# Altium schematic sheet RC96_sht4.SchDoc
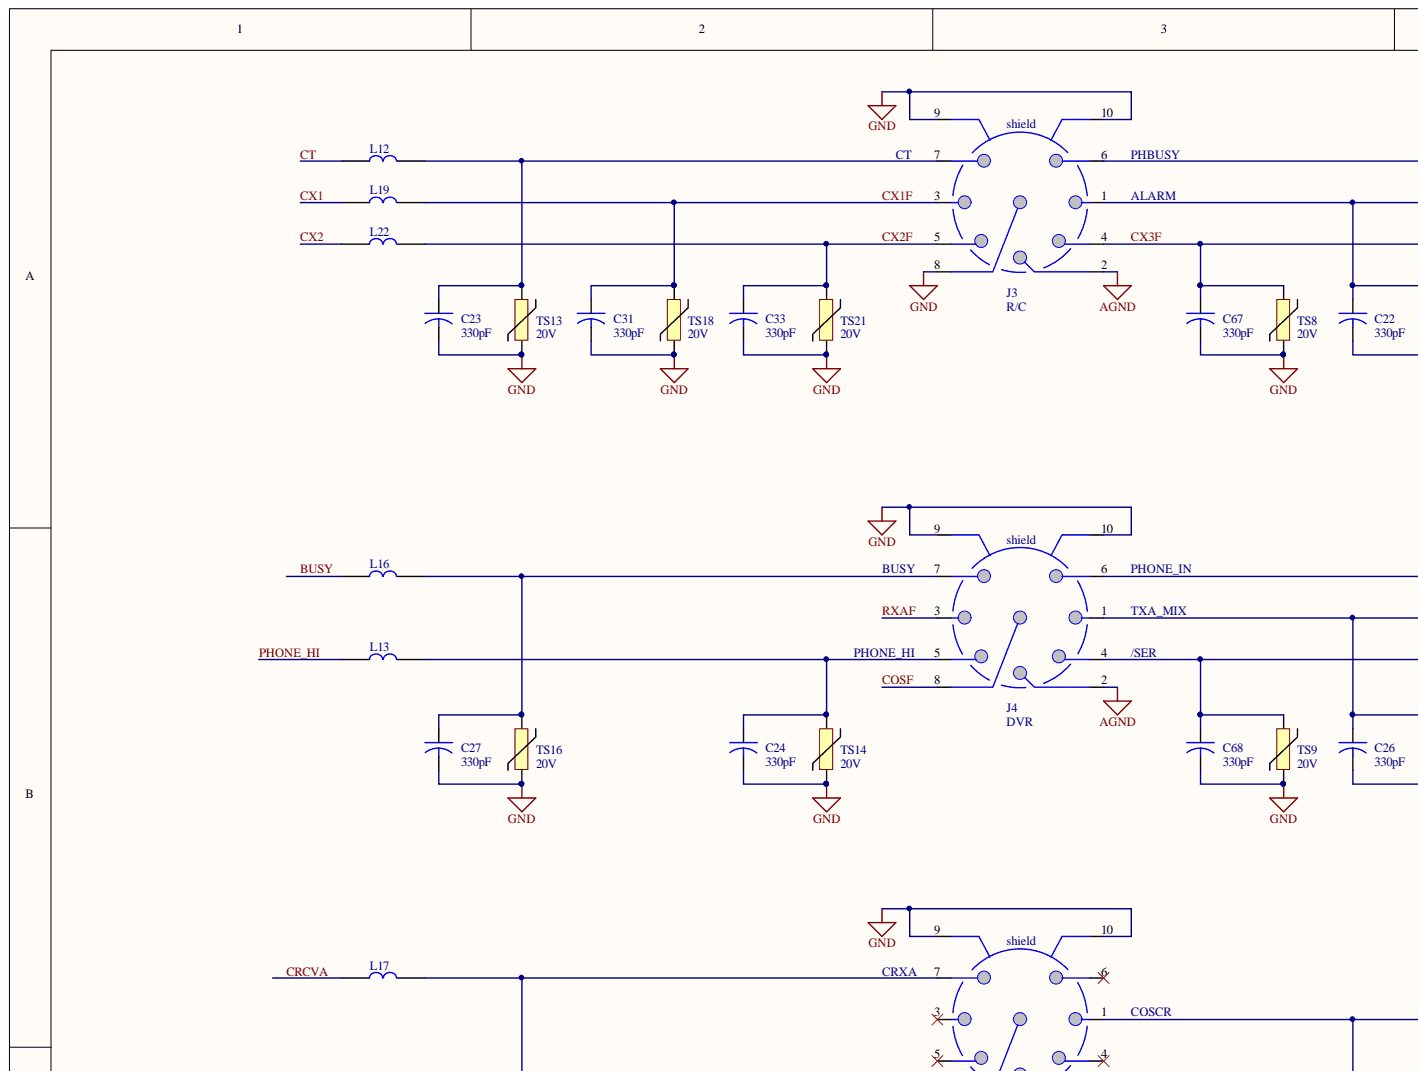
[diagram p0: RC96_sht4.SchDoc, top left]
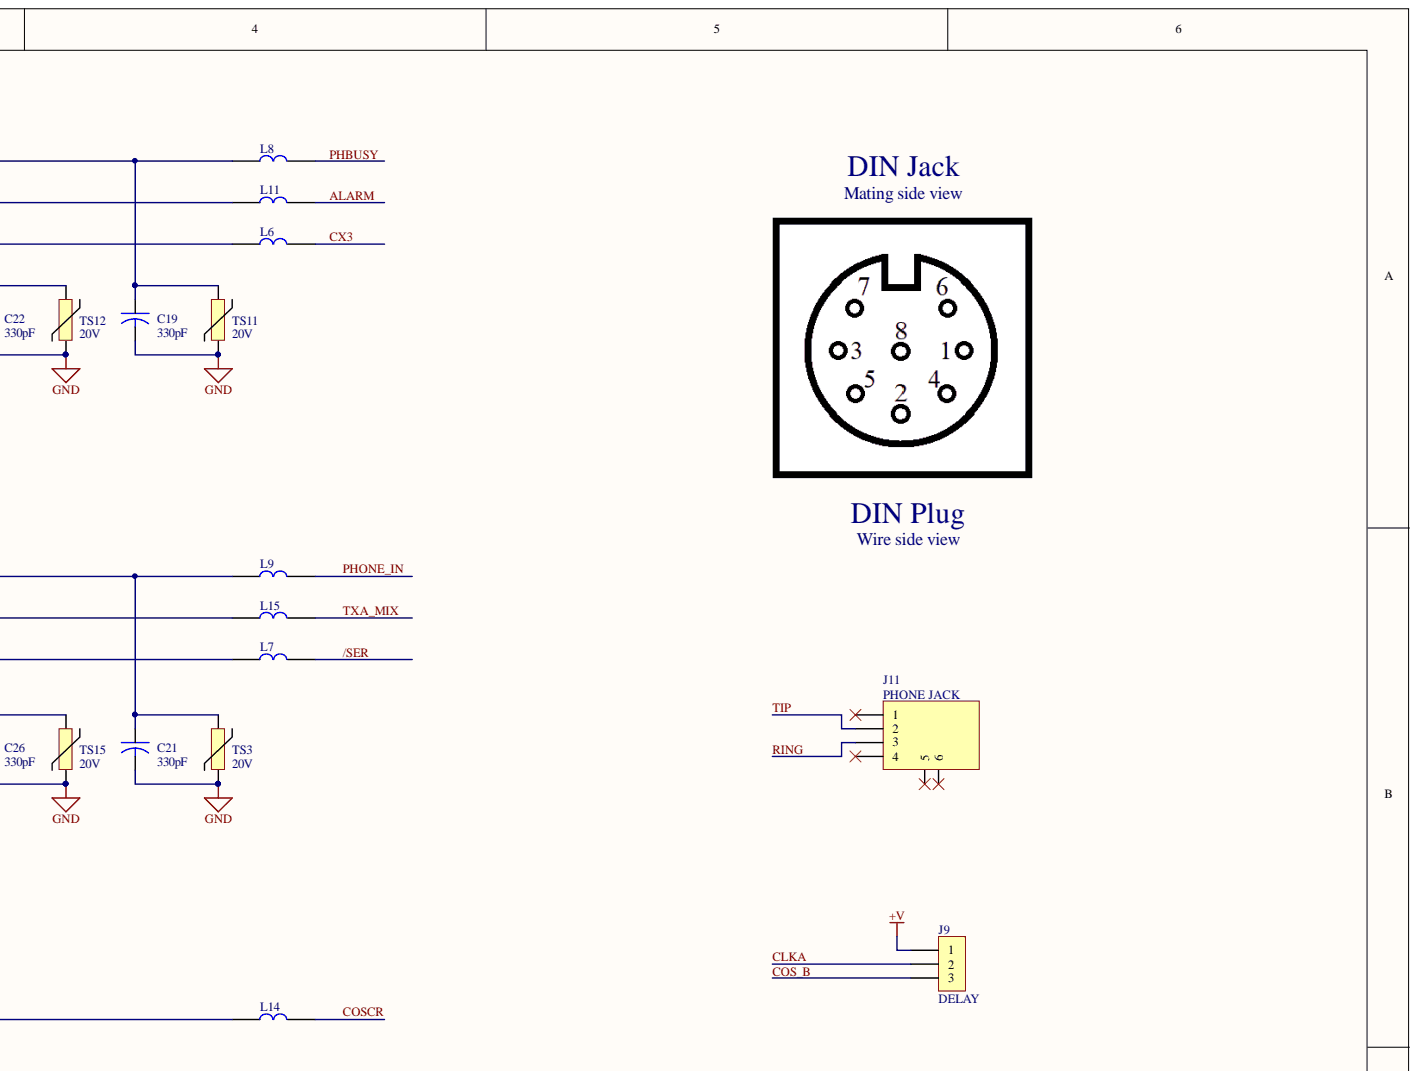
[diagram p1: RC96_sht4.SchDoc, top right]
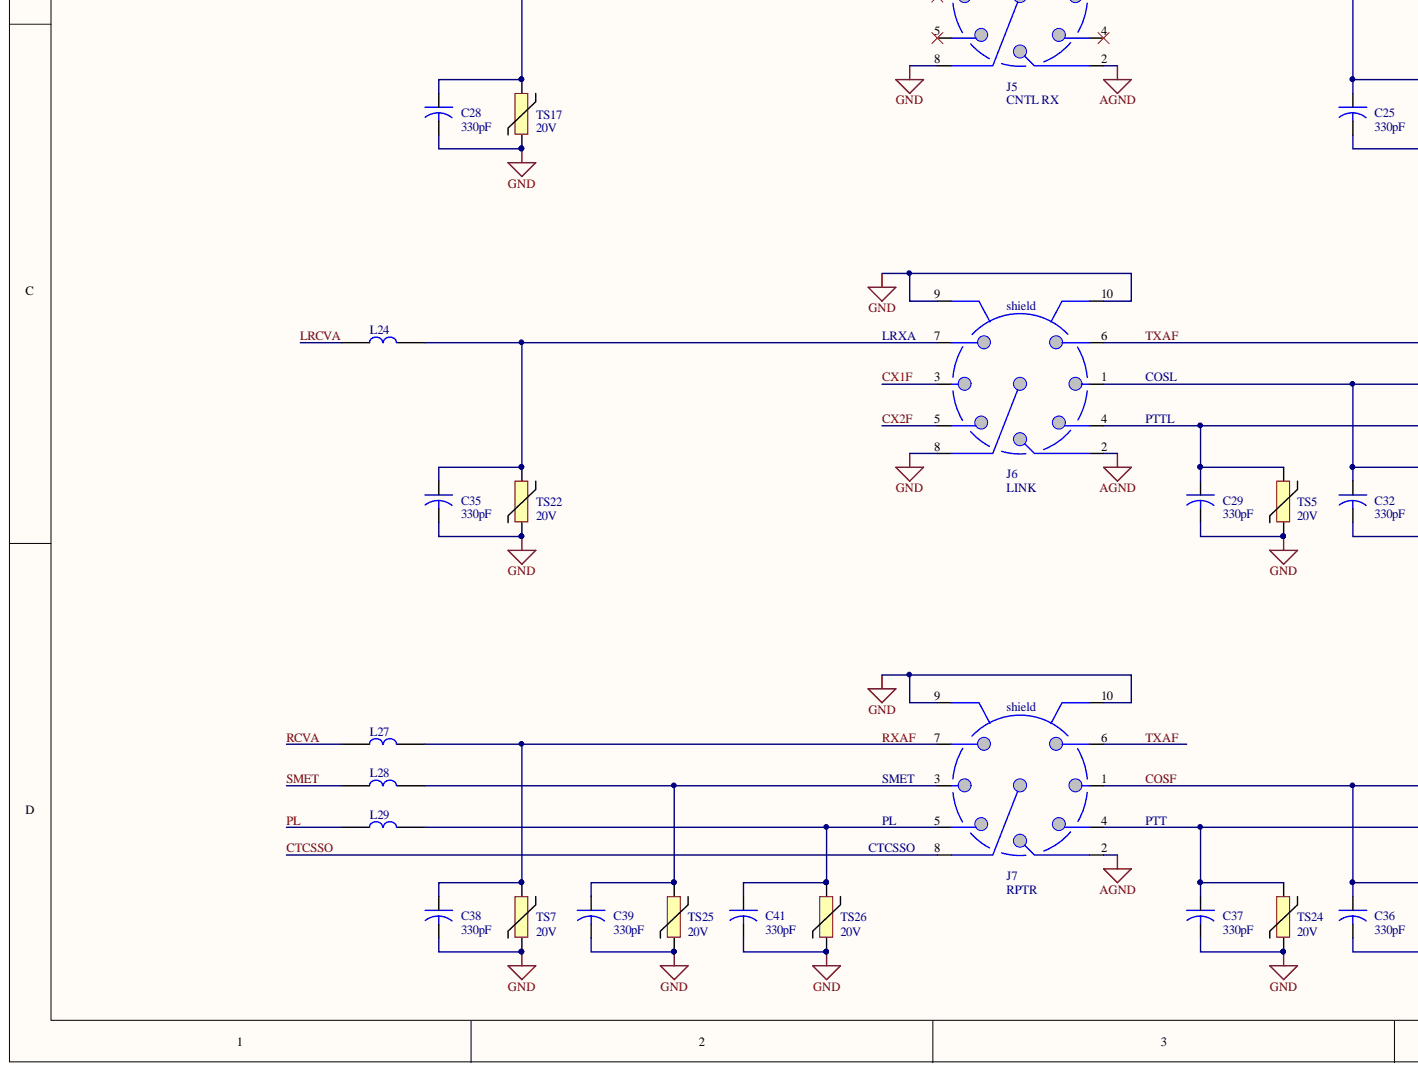
[diagram p2: RC96_sht4.SchDoc, bottom left]
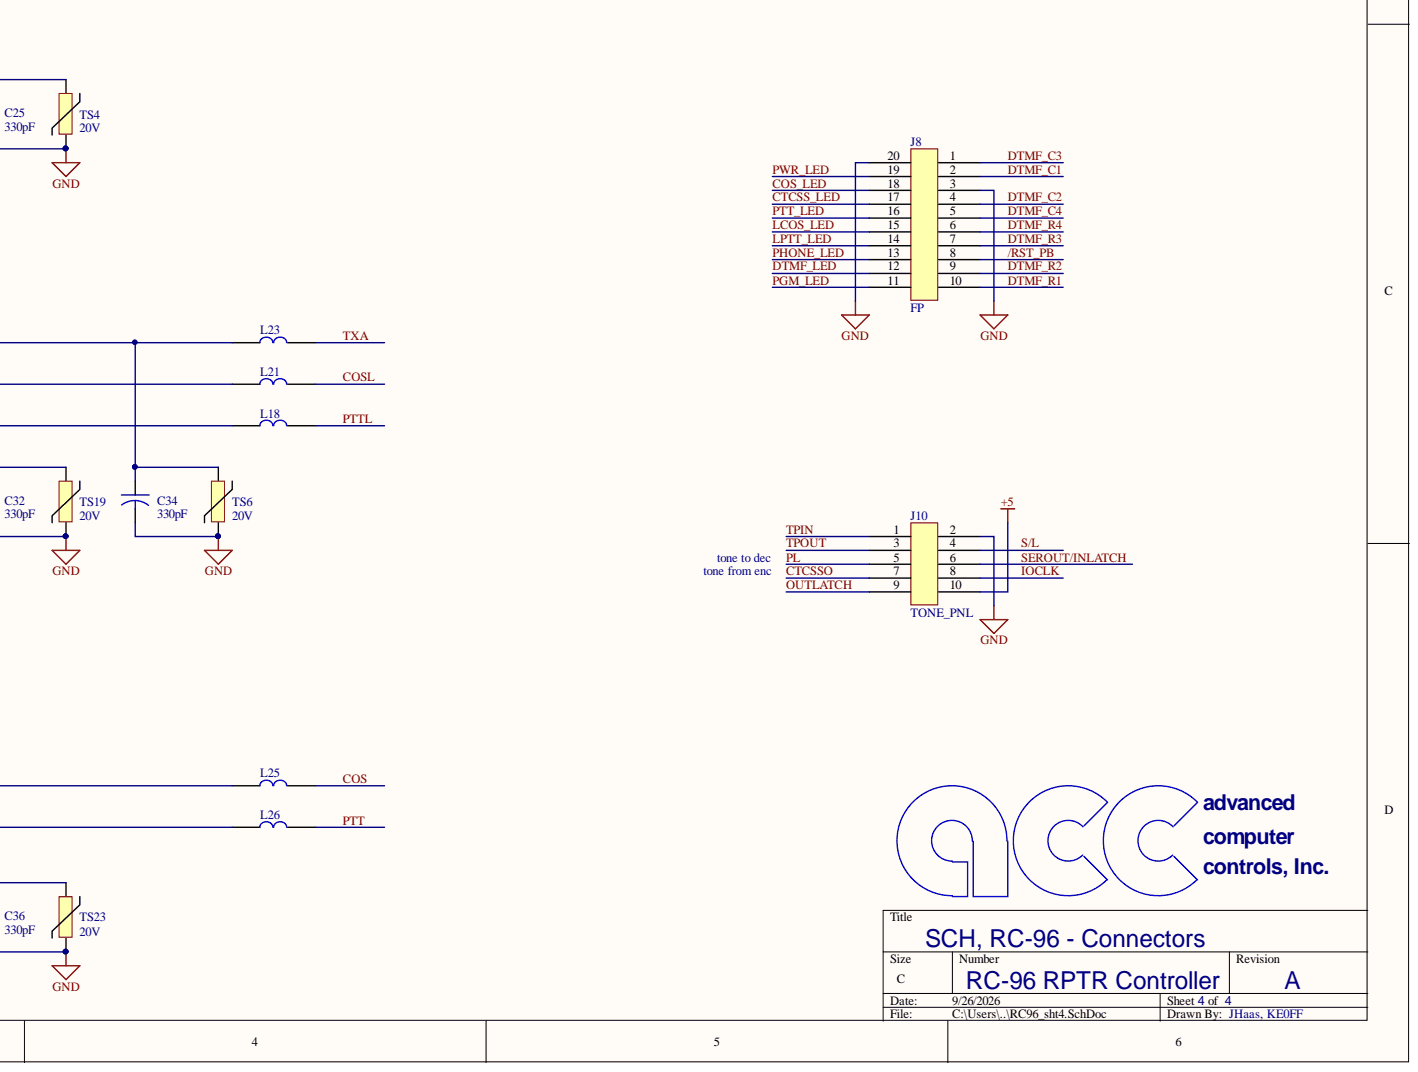
[diagram p3: RC96_sht4.SchDoc, bottom right]

## Parts
C19  330pF  [RAD2]
C21  330pF  [RAD2]
C22  330pF  [RAD2]
C23  330pF  [RAD2]
C24  330pF  [RAD2]
C25  330pF  [RAD2]
C26  330pF  [RAD2]
C27  330pF  [RAD2]
C28  330pF  [RAD2]
C29  330pF  [RAD2]
C31  330pF  [RAD2]
C32  330pF  [RAD2]
C33  330pF  [RAD2]
C34  330pF  [RAD2]
C35  330pF  [RAD2]
C36  330pF  [RAD2]
C37  330pF  [RAD2]
C38  330pF  [RAD2]
C39  330pF  [RAD2]
C41  330pF  [RAD2]
C67  330pF  [RAD2]
C68  330pF  [RAD2]
J3  R/C  [DIN8_RA]
J4  DVR  [DIN8_RA]
J5  CNTL RX  [DIN8_RA]
J6  LINK  [DIN8_RA]
J7  RPTR  [DIN8_RA]
J8  FP  [HDR20]
J9  DELAY  [MOLEX3_156]
J10  TONE_PNL  [HDR10]
J11  PHONE JACK  [RJ11_RA]
L6  120ohm  [AXIAL6]  (value not drawn)
L7  120ohm  [AXIAL6]  (value not drawn)
L8  120ohm  [AXIAL6]  (value not drawn)
L9  120ohm  [AXIAL6]  (value not drawn)
L11  120ohm  [AXIAL6]  (value not drawn)
L12  120ohm  [AXIAL6]  (value not drawn)
L13  120ohm  [AXIAL6]  (value not drawn)
L14  120ohm  [AXIAL6]  (value not drawn)
L15  120ohm  [AXIAL6]  (value not drawn)
L16  120ohm  [AXIAL6]  (value not drawn)
L17  120ohm  [AXIAL6]  (value not drawn)
L18  120ohm  [AXIAL6]  (value not drawn)
L19  120ohm  [AXIAL6]  (value not drawn)
L21  120ohm  [AXIAL6]  (value not drawn)
L22  120ohm  [AXIAL6]  (value not drawn)
L23  120ohm  [AXIAL6]  (value not drawn)
L24  120ohm  [AXIAL6]  (value not drawn)
L25  120ohm  [AXIAL6]  (value not drawn)
L26  120ohm  [AXIAL6]  (value not drawn)
L27  120ohm  [AXIAL6]  (value not drawn)
L28  120ohm  [AXIAL6]  (value not drawn)
L29  120ohm  [AXIAL6]  (value not drawn)
TS3  20V  [RAD2W]
TS4  20V  [RAD2W]
TS5  20V  [RAD2W]
TS6  20V  [RAD2W]
TS7  20V  [RAD2W]
TS8  20V  [RAD2W]
TS9  20V  [RAD2W]
TS11  20V  [RAD2W]
TS12  20V  [RAD2W]
TS13  20V  [RAD2W]
TS14  20V  [RAD2W]
TS15  20V  [RAD2W]
TS16  20V  [RAD2W]
TS17  20V  [RAD2W]
TS18  20V  [RAD2W]
TS19  20V  [RAD2W]
TS21  20V  [RAD2W]
TS22  20V  [RAD2W]
TS23  20V  [RAD2W]
TS24  20V  [RAD2W]
TS25  20V  [RAD2W]
TS26  20V  [RAD2W]

## Nets
+5: J10.10
+V: J9.1
AGND: J3.2 J4.2 J5.2 J6.2 J7.2
GND: C19.2 C21.2 C22.2 C23.2 C24.2 C25.2 C26.2 C27.2 C28.2 C29.2 C31.2 C32.2 C33.2 C34.2 C35.2 C36.2 C37.2 C38.2 C39.2 C41.2 C67.2 C68.2 J3.8 J3.9 J3.10 J4.9 J4.10 J5.8 J5.9 J5.10 J6.8 J6.9 J6.10 J7.9 J7.10 J8.3 J8.20 J10.2 TS3.2 TS4.2 TS5.2 TS6.2 TS7.2 TS8.2 TS9.2 TS11.2 TS12.2 TS13.2 TS14.2 TS15.2 TS16.2 TS17.2 TS18.2 TS19.2 TS21.2 TS22.2 TS23.2 TS24.2 TS25.2 TS26.2
/RST_PB: J8.8
/SER: L7.2
ALARM: L11.2
BUSY: L16.1
CLKA: J9.2
COS: L25.2
COS_B: J9.3
COS_LED: J8.18
COSCR: L14.2
COSF: C36.1 J4.8 J7.1 L25.1 TS23.1
COSL: L21.2
CRCVA: L17.1
CT: L12.1
CTCSS_LED: J8.17
CTCSSO: J7.8 J10.7
CX1: L19.1
CX1F: C31.1 J3.3 J6.3 L19.2 TS18.1
CX2: L22.1
CX2F: C33.1 J3.5 J6.5 L22.2 TS21.1
CX3: L6.2
CX3F: C67.1 J3.4 L6.1 TS8.1
DTMF_C1: J8.2
DTMF_C2: J8.4
DTMF_C3: J8.1
DTMF_C4: J8.5
DTMF_LED: J8.12
DTMF_R1: J8.10
DTMF_R2: J8.9
DTMF_R3: J8.7
DTMF_R4: J8.6
IOCLK: J10.8
LCOS_LED: J8.15
LPTT_LED: J8.14
LRCVA: L24.1
OUTLATCH: J10.9
PGM_LED: J8.11
PHBUSY: L8.2
PHONE_HI: L13.1
PHONE_IN: L9.2
PHONE_LED: J8.13
PL: J10.5 L29.1
PTT: L26.2
PTT_LED: J8.16
PTTL: L18.2
PWR_LED: J8.19
RCVA: L27.1
RING: J11.3
RXAF: C38.1 J4.3 J7.7 L27.2 TS7.1
S/L: J10.4
SEROUT/INLATCH: J10.6
SMET: L28.1
TIP: J11.2
TPIN: J10.1
TPOUT: J10.3
TXA: L23.2
TXA_MIX: L15.2
TXAF: C34.1 J6.6 J7.6 L23.1 TS6.1
NetC19_1: C19.1 J3.6 L8.1 TS11.1
NetC21_1: C21.1 J4.6 L9.1 TS3.1
NetC22_1: C22.1 J3.1 L11.1 TS12.1
NetC23_1: C23.1 J3.7 L12.2 TS13.1
NetC24_1: C24.1 J4.5 L13.2 TS14.1
NetC25_1: C25.1 J5.1 L14.1 TS4.1
NetC26_1: C26.1 J4.1 L15.1 TS15.1
NetC27_1: C27.1 J4.7 L16.2 TS16.1
NetC28_1: C28.1 J5.7 L17.2 TS17.1
NetC29_1: C29.1 J6.4 L18.1 TS5.1
NetC32_1: C32.1 J6.1 L21.1 TS19.1
NetC35_1: C35.1 J6.7 L24.2 TS22.1
NetC37_1: C37.1 J7.4 L26.1 TS24.1
NetC39_1: C39.1 J7.3 L28.2 TS25.1
NetC41_1: C41.1 J7.5 L29.2 TS26.1
NetC68_1: C68.1 J4.4 L7.1 TS9.1

Not connected: J5 3 4 5 6; J11 1 4 5 6

## Library data of the parts
C19 C21-C29 C31-C39 C41 C67 C68 = CAP 0805: CAP, TANT, 0805, 50V, 1uF; ALT-MFR: -; ALT-MPN: -; Component Kind: Standard; DNP: -; MFR: -; MPN: -; Library Name: Galaxy SCH-jem-1.08.SchLib
J3-J7 = CONN_DIN8: Component Kind: Standard
J8 = CON_20P_DIP: CON, DIP, 20 POS, 0.1" LS; ALT-MPN: -; Component Kind: Standard; DNP: -; MFR: -; MPN: -; NOTES: -; NOTES2: -; PRI-MPN: -; Library Name: Galaxy SCH-jem-1.08.SchLib
J9 = CON3: Connector, 3 pin; ALT-MPN: -; Component Kind: Standard; DNP: -; MFR: -; MPN: -; NOTES: -; NOTES2: -; PRI-MPN: -; Library Name: Galaxy SCH-jem-1.08.SchLib
J10 = CON_10P_050: CON, DIP, 10 POS, 0.05" LS; ALT-MPN: -; Component Kind: Standard; DNP: -; MFR: -; MPN: -; NOTES: -; NOTES2: -; PRI-MPN: -; Library Name: Galaxy SCH-jem-1.08.SchLib
J11 = CON_RJ-11_MTG2: Connector, RJ-11, 2 mtg pads; ALT-MPN: -; Component Kind: Standard; DNP: -; MFR: -; MPN: -; NOTES: -; NOTES2: -; PRI-MPN: -; Library Name: Galaxy SCH-jem-1.08.SchLib
L6-L9 L11-L19 L21-L29 = FB: BD, FRT, SMD; ALT-MPN: -; Component Kind: Standard; DNP: -; MFR: -; MPN: -; NOTES: -; NOTES2: -; PRI-MPN: -; Library Name: Galaxy SCH-jem-1.08.SchLib
TS3-TS9 TS11-TS19 TS21-TS26 = MOV: MOV, TH, 20V; ALT-MPN: -; Component Kind: Standard; DNP: -; MFR: -; MPN: -; NOTES: -; NOTES2: -; PRI-MPN: -; Library Name: Galaxy SCH-jem-1.08.SchLib
